# Altium schematic sheet ActiTouch.SchDoc(ActiTouch)
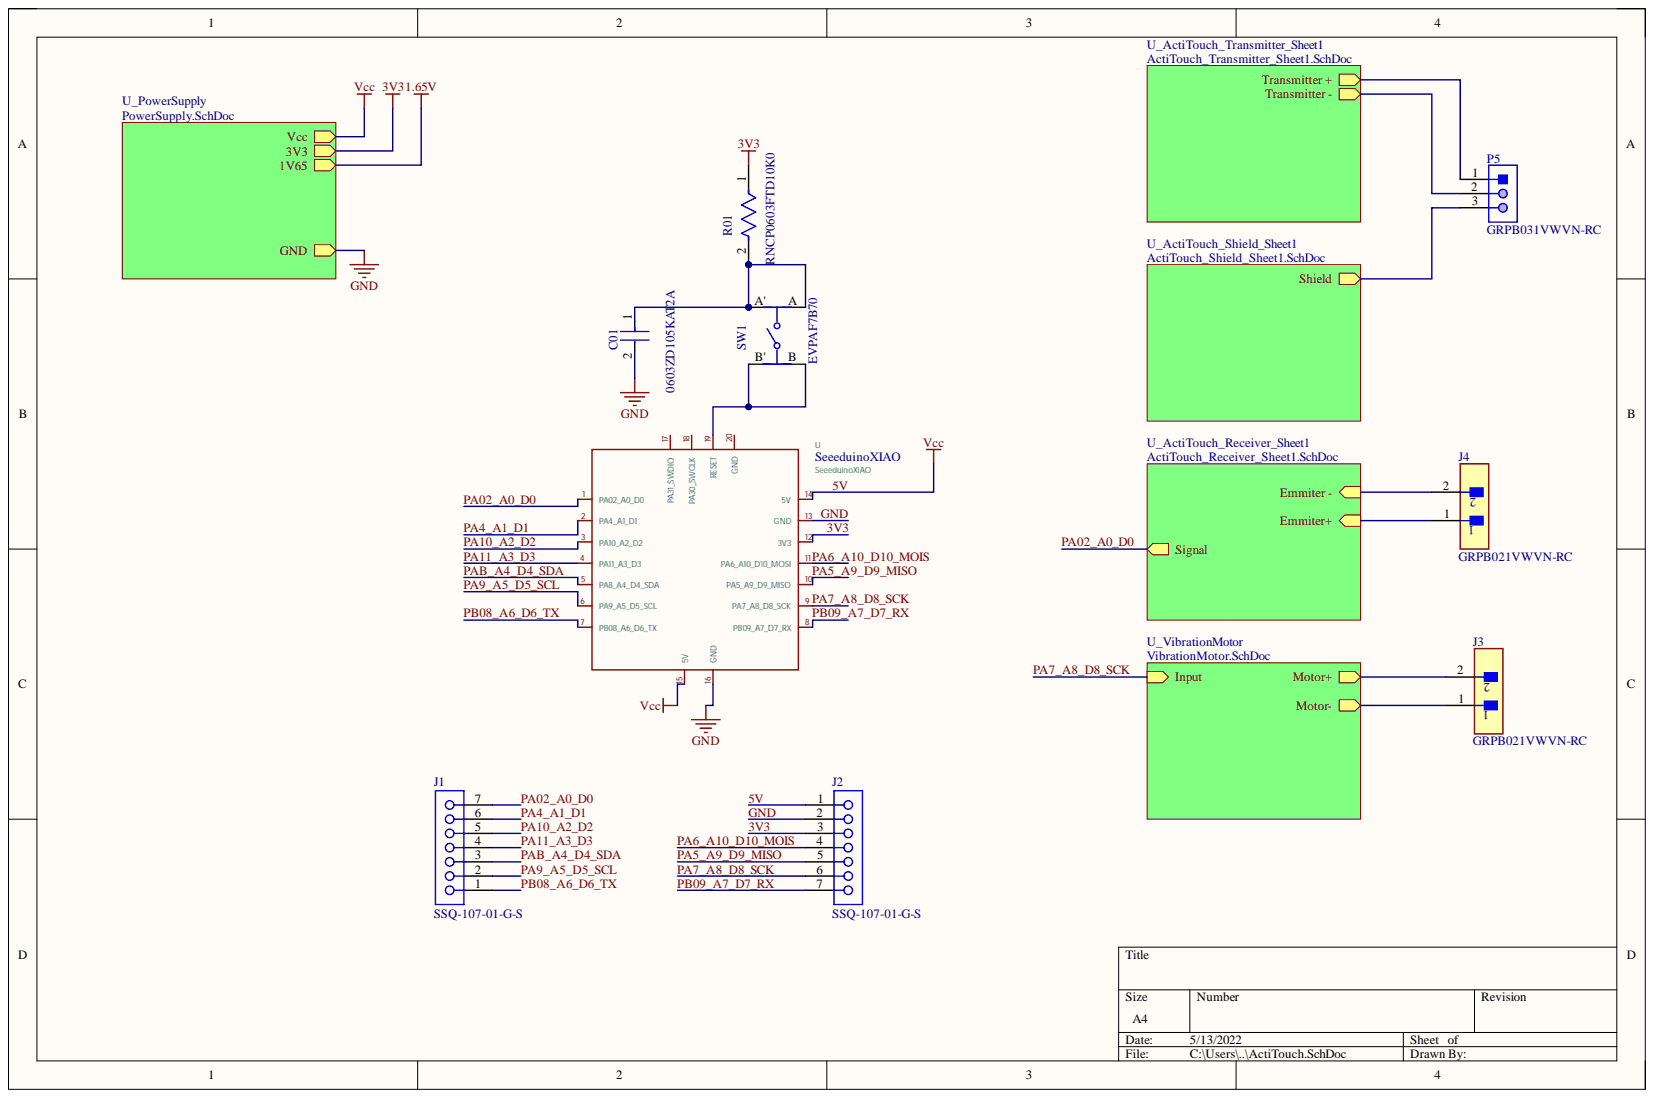
[diagram p0: ActiTouch.SchDoc]

## Parts
C01  0603ZD105KAT2A  [FP-0603-L_1_6_0_15-W_0_81-IPC_A]
J1  SSQ-107-01-G-S  [SMTC-SSQ-107-01-X-S]
J2  SSQ-107-01-G-S  [SMTC-SSQ-107-01-X-S]
J3  GRPB021VWVN-RC  [FP-GRPB021VWVN-RC-MFG]
J4  GRPB021VWVN-RC  [FP-GRPB021VWVN-RC-MFG]
P5  GRPB031VWVN-RC  [SLIN-GRPB031VWVN-RC_V]
R01  RNCP0603FTD10K0  [FP-RNCP0603-MFG]
SW1  EVPAF7B70  [FP-EVPAF7B70-MFG]
U  SeeeduinoXIAO

## Nets
3V3: J2.3 R01.1 U.12(3V3)
5V: J2.1 U.14(5V) U.15(5V)
GND: C01.2 J2.2 U.13(GND) U.16(GND)
PA02_A0_D0: J1.7 U.1(PA02_A0_D0)
PA4_A1_D1: J1.6 U.2(PA4_A1_D1)
PA5_A9_D9_MISO: J2.5 U.10(PA5_A9_D9_MISO)
PA6_A10_D10_MOIS: J2.4 U.11(PA6_A10_D10_MOSI)
PA7_A8_D8_SCK: J2.6 U.9(PA7_A8_D8_SCK)
PA9_A5_D5_SCL: J1.2 U.6(PA9_A5_D5_SCL)
PA10_A2_D2: J1.5 U.3(PA10_A2_D2)
PA11_A3_D3: J1.4 U.4(PA11_A3_D3)
PAB_A4_D4_SDA: J1.3 U.5(PA8_A4_D4_SDA)
PB08_A6_D6_TX: J1.1 U.7(PB08_A6_D6_TX)
PB09_A7_D7_RX: J2.7 U.8(PB09_A7_D7_RX)
NetC01_1: C01.1 R01.2 SW1.A(A') SW1.A'(A)
NetJ3_1: J3.1
NetJ3_2: J3.2
NetJ4_1: J4.1
NetJ4_2: J4.2
NetP5_1: P5.1
NetP5_2: P5.2
NetP5_3: P5.3
NetSW1_B: SW1.B SW1.B' U.19(RESET)
NetU_17: U.17(PA31_SWDIO)
NetU_18: U.18(PA30_SWCLK)
NetU_20: U.20(GND)
1.65V:

## Library data of the parts
C01 = CMP-2006-04559-3: General Purpose Ceramic Capacitor, 0603, 1uF, 10%, X5R, 15%, 10V; Dissipation Factor: 5%; Insulation Resistance: 100GR; Capacitance: 1uF; Case Code (Imperial): 0603; Case Code (Metric): 1608; Case/Package: 0603; Component Kind: Standard; Construction: Flat; Contact Plating: Tin; Depth: 0.81mm; Dielectric: X5R; Height: 0.9mm; Lead Free: Lead Free; Length: 1.6mm; Library Name: Altium Content Vault; Max Operating Temperature: 85°C; Min Operating Temperature: -55°C; Mount: Surface Mount; Number of Pins: 2; Package Quantity: 4000; Packaging: Tape and Reel; Radiation Hardening: No; REACH SVHC: No SVHC; RoHS Compliant: Yes; Series: -; Termination: SMD/SMT; Thickness: 0.035inch; Tolerance: 10%; Voltage: 10V; Voltage Rating: 10V; Voltage Rating (DC): 10V; Width: 0.81mm
J1 J2 = CMP-1023-00284-1: SQ Post Socket, Through-hole, Vertical, -55 to 125 degC, 2.54 mm Pitch, 7-Pin, Female, RoHS; Manufacturer: Samtec; Pitch: 2.54 mm; Component Kind: Standard; ComponentLink1Description: Manufacturer URL; ComponentLink1URL: http://www.samtec.com; ComponentLink2Description: Datasheet; ComponentLink2URL: http://www.samtec.com/documents/webfiles/pdf/SSQ_TH.PDF; ComponentLink3Description: Package Specification; ComponentLink3URL: http://www.samtec.com/documents/webfiles/cpdf/SSQ-1XX-XX-XXX-X-XX-XXX-XX-X-MKT.pdf; DatasheetVersion: F-214; Library Name: Altium Content Vault; Mounting Technology: Through-hole; Orientation: Vertical; PackageDescription: Assembly, Socket Strip .025[0.64] SQ Tail; PackageReference: SSQ-107-01-X-S; PackageVersion: BD, 6/1987; PartNumber: SSQ-107-01-G-S; RoHS: True; Temperature Range: -55 to 125 degC
J3 J4 = CMP-2000-06371-2: CONN HEADER VERT 2POS 1.27MM; Connector Type: Male Pin; Number of Positions: 2; Pitch: 0.05inch; Component Kind: Standard; Contact Mating Length: 0.118inch; Contact Plating: Gold; Contact Type: Male Pin; Lead Free: Lead Free; Library Name: Altium Content Vault; Mount: Through Hole; Number of Rows: 1; Package Quantity: 1; Packaging: Bulk; RoHS Compliant: Yes; Termination: Solder
P5 = CMP-2000-06372-1: Male Header, Pitch 1.27 mm, 1 x 3 Position, Height 4 mm, Tail Length 2.3 mm, -40 to 105 degC, RoHS, Bulk; Manufacturer: Sullins; Catalog Drawings: S9014ESeriesTop; Connector Type: Header,Unshrouded; Dynamic Catalog: GRPB; Mating Products: S9010E-03-ND  -CONNHEADER.050"3POSR/APCB  S9008E-03-ND  -CONNHEADER.050"3POSPCBGOLD  S9005E-03-ND  -CONNHEADER.050"3POSSMDGOLD; Number of Positions: 3; Number of Positions Loaded: All; Other Names: S9014E-03; Pitch: 0.050"(1.27mm); Category: Connectors,Interconnects; Component Kind: Standard; Contact Finish: Gold; Contact Finish Thickness: Flash; Contact Mating Length: 0.118"(3.00mm); Contact Type: MalePin; Datasheet URL: http://www.sullinscorp.com/drawings/71_GRPB___1VWVN-RC,_10957-C.pdf; Datasheet Version: Rev. C, 12/2008; Family: RectangularConnectors-Headers,MalePins; Library Name: Altium Content Vault; Manufacturer URL: http://www.sullinscorp.com/; Mounting Technology: ThroughHole; Mounting Type: ThroughHole; Number of Rows: 1; Package Description: Male Header, Pitch 1.27 mm, 1 x 3 Position, Height 4 mm, Tail Length 2.3 mm; Package Reference: GRPB031VWVN-RC; Packaging: Bulk; Standard Package: 1; Termination: Solder
R01 = CMP-26527-000144-1: 12.4kΩ ±1% 0.125W 0603 High Power Anti-Sulfur Thin Film Chip Resistor; Max Power Dissipation: 125mW; Resistance: 12.4kR; Temperature Coefficient: 100ppm/°C; Case Code (Imperial): 0603; Case Code (Metric): 1608; Case/Package: 0603; Component Kind: Standard; Features: Moisture Resistant; Height: 0.02inch; Length: 0.059inch; Library Name: Altium Content Vault; Max Operating Temperature: 155°C; Min Operating Temperature: -55°C; Mount: Surface Mount; Number of Terminations: 2; Packaging: Cut Tape; Resistor Type: Thin Film; RoHS Compliant: Yes; Series: RNCP; Tolerance: 1%; Width: 0.031inch
SW1 = CMP-05618-000093-1: SWITCH TACTILE SPST-NO 0.02A 15V; Actuator Length: 0.22mm; Actuator Type: Standard; Contact Resistance: 500mR; Current Rating: 50mA; Electrical Life: 500000Cycles; Illumination Type: Non-Illuminated; Max Current Rating: 20mA; Operating Force: 2.4N; Switch Function: Off-Mom; Switch Travel: 0.15mm; Throw Configuration: SPST; Case/Package: SMD/SMT; Color: Black; Component Kind: Standard; Contact Plating: Silver; Depth: 3.4mm; Height: 0.7mm; Length: 2.6mm; Library Name: Altium Content Vault; Max Operating Temperature: 85°C; Max Voltage Rating (DC): 15V; Mechanical Life: 500000Cycles; Min Operating Temperature: -40°C; Mount: Surface Mount; Orientation: Straight; Package Quantity: 1; Packaging: Tape and Reel; Radiation Hardening: No; REACH SVHC: No SVHC; Series: EVPAF; Size: 2.6mm; Termination: J-Bend; Voltage Rating (DC): 15V
U = SeeeduinoXIAO: Component Kind: Standard; Library Name: Seeeduino XIAO.SchLib; Value: SeeeduinoXIAO
